# Altium schematic sheet sEMG_PowerManagment.SchDoc
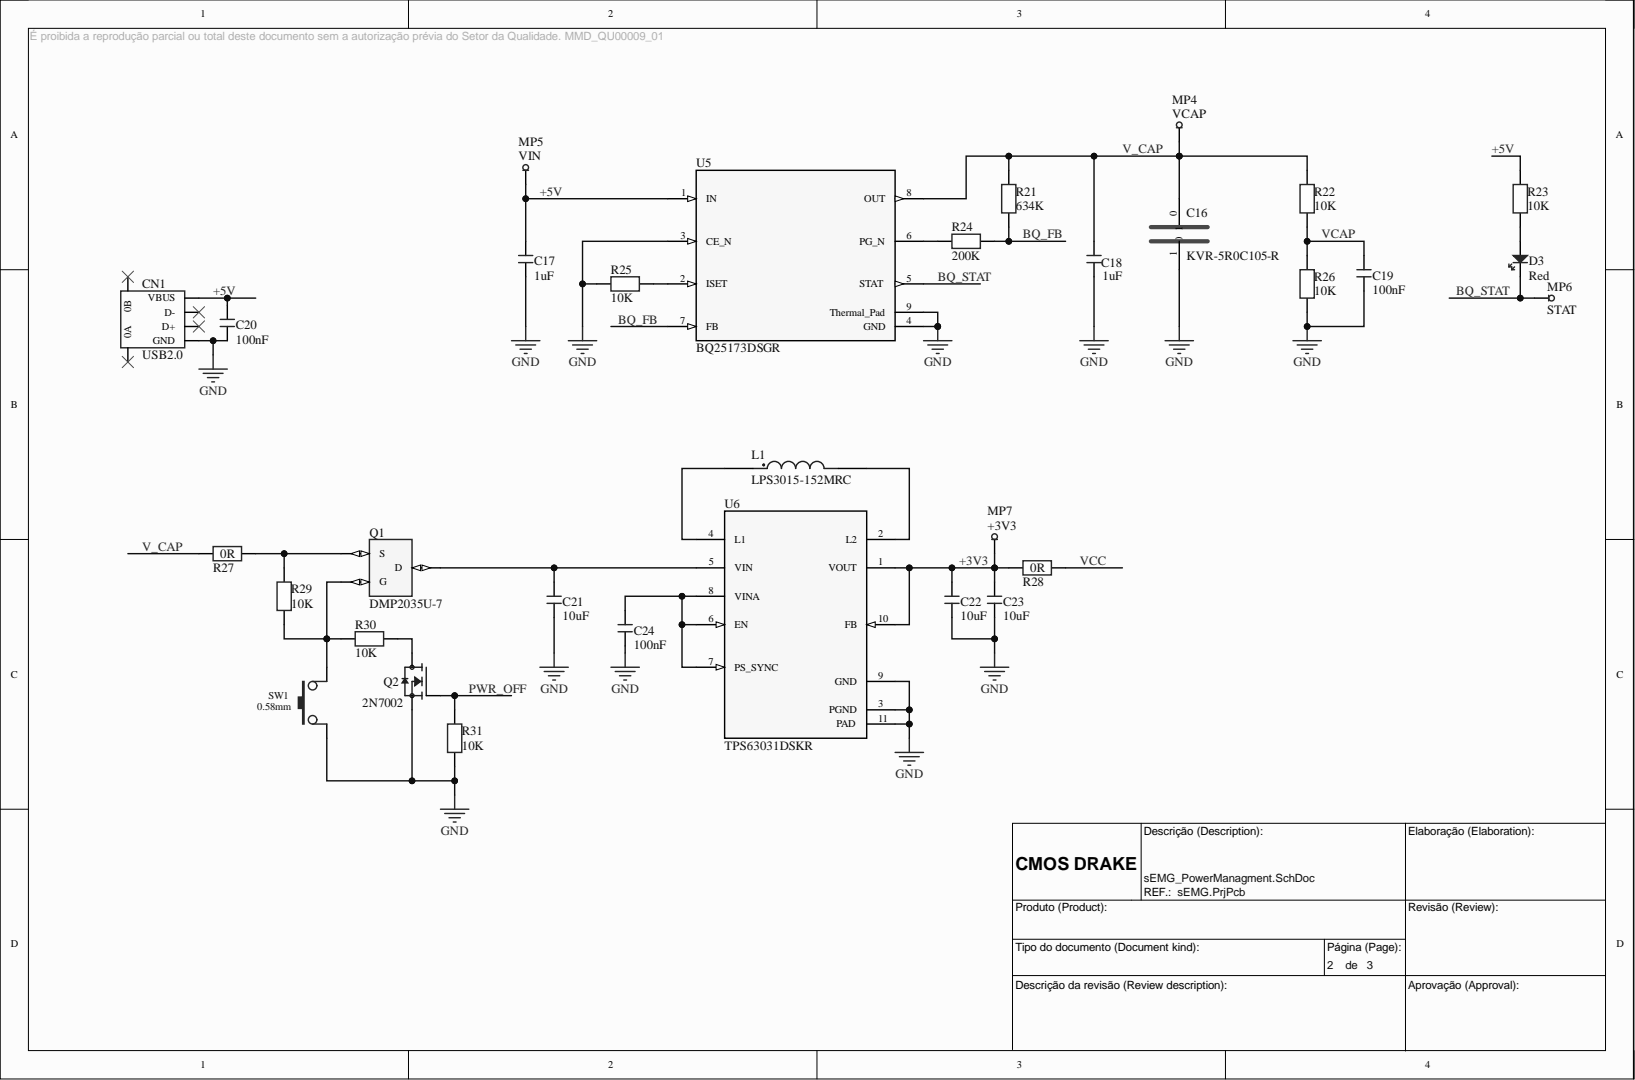
[diagram p0: sEMG_PowerManagment.SchDoc]

## Parts
C16  KVR-5R0C105-R
C17  1uF  [CAP0402[1005]MD]
C18  1uF  [CAP0402[1005]MD]
C19  100nF  [CAP0603[1608]MD-BO]
C20  100nF  [CAP0603[1608]MD-BO]
C21  10uF  [CAP0603[1608]MD]
C22  10uF  [CAP0603[1608]MD]
C23  10uF  [CAP0603[1608]MD]
C24  100nF  [CAP0603[1608]MD-BO]
CN1  USB2.0  [USB_TIPO B]
D3  Red  [LEDP0603RED]
L1  LPS3015-152MRC  [IND_LPS3015-152MRC]
MP4  VCAP  [MP1.02MM-RED]
MP5  VIN  [MP1.02MM-RED]
MP6  STAT  [MP1.02MM-RED]
MP7  +3V3  [MP1.02MM-RED]
Q1  DMP2035U-7  [SOT23]
Q2  2N7002  [TRANS_2N7002]
R21  634K  [RES0805[2012]MD]
R22  10K  [RES0805[2012]MD]
R23  10K  [RES0805[2012]MD]
R24  200K  [RES0805[2012]MD]
R25  10K  [RES0805[2012]MD]
R26  10K  [RES0805[2012]MD]
R27  0R  [RES0603[1608]MD-BO]
R28  0R  [RES0603[1608]MD-BO]
R29  10K  [RES0805[2012]MD]
R30  10K  [RES0805[2012]MD]
R31  10K  [RES0805[2012]MD]
SW1  0.58mm  [Tactile switch 6x6x7mm]
U5  BQ25173DSGR  [DSG0008A-IPC_A]
U6  TPS63031DSKR  [DSK0010A]

## Nets
GND: C16.1 C17.2 C18.2 C19.2 C20.2 C21.2 C22.2 C23.2 C24.2 CN1.4(GND) Q2.2 R25.2 R26.1 R31.2 SW1.1 U5.3(CE_N) U5.4(GND) U5.9(Thermal_Pad) U6.3(PGND) U6.9(GND) U6.11(PAD)
+3V3: C22.1 C23.1 MP7.1 R28.1 U6.1(VOUT) U6.10(FB)
+5V: C17.1 C20.1 CN1.1(VBUS) MP5.1 R23.2 U5.1(IN)
BQ_FB: R21.2 R24.2 U5.7(FB)
BQ_STAT: D3.1 MP6.1 U5.5(STAT)
PWR_OFF: Q2.1 R31.1
V_CAP: C16.0 C18.1 MP4.1 R21.1 R22.2 R27.1 U5.8(OUT)
VCAP: C19.1 R22.1 R26.2
VCC: R28.2
NetC21_1: C21.1 Q1.3 U6.5(VIN)
NetC24_1: C24.1 U6.6(EN) U6.7(PS_SYNC) U6.8(VINA)
NetD3_2: D3.2 R23.1
NetL1_1: L1.1 U6.4(L1)
NetL1_2: L1.2 U6.2(L2)
NetQ1_1: Q1.1 R29.2 R30.1 SW1.2
NetQ1_2: Q1.2 R27.2 R29.1
NetQ2_3: Q2.3 R30.2
NetR24_1: R24.1 U5.6(PG_N)
NetR25_1: R25.1 U5.2(ISET)

Not connected: CN1 0A 0B 2(D-) 3(D+)

## Library data of the parts
C16 = KVR-5R0C105-R: KVR-5R0C105-R 1F 5V  SUPERCAP; Component Kind: Standard; Library Name: KVR-5R0C105-R.SchLib
C17 C18 = SMD 0402 1uF 16V 10% X5R: CERAMIC SMD 0402 1uF 16V 10% X5R; Manufacturer 1: TDK; Part Number 1: C1005X5R1C105K; Component Kind: Standard; Library Name: Capacitors.SCHLIB; Manufacturer 2: Taiyo Yuden; Manufacturer 3: AVX; Part Number 2: EMK105BJ105KV-F; Part Number 3: 0402YD105KAT2A
C19 C20 C24 = SMD 0603 100nF 50V 5% X7R: CERAMIC SMD 0603 100nF 50V 5% X7R; Manufacturer 1: Samsung Electro-Mechanics America, Inc.; Part Number 1: CL10B104JB8NNNC; Component Kind: Standard; Library Name: Capacitors.SCHLIB; Manufacturer 2: Yageo; Manufacturer 3: AVX Corporation; Manufacturer 4: Murata Electronics North America; Manufacturer 5: KEMET; Part Number 2: CC0603JRX7R9BB104; Part Number 3: 06035C104JAT2A; Part Number 4: GRM188R71H104JA93D; Part Number 5: C0603C104J5RACTU
C21-C23 = SMD 0603 10uF 6.3V 10% X5R: CERAMIC SMD 0603 10uF 6.3V 10% X5R; Manufacturer 1: TDK Corporation; Part Number 1: C1608X5R0J106K; Component Kind: Standard; Library Name: Capacitors.SCHLIB
CN1 = USB2.0 B-SH-90: USB2.0 B SHIELDED; Component Kind: Standard; Library Name: Connectors.SCHLIB
D3 = LED VERMELHO SMD 0603 CLR: LED RED CLEAR 0603 SMD; Manufacturer 1: Kingbright Corp; Part Number 1: APT1608EC; Component Kind: Standard; Library Name: Diodes.SchLib
L1 = LPS3015-152MRC: Power inductor, shielded, 20% tol, SMT, RoHS, lead-free, halogen-free; MF: Coilcraft; Check_prices: https://www.snapeda.com/parts/LPS3015-152MRC/Coilcraft/view-part/?ref=eda; Component Kind: Standard; Library Name: LPS3015-152MRC.IntLib; MP: LPS3015-152MRC; Package: None; SnapEDA_Link: https://www.snapeda.com/parts/LPS3015-152MRC/Coilcraft/view-part/?ref=snap
MP4-MP7 = MP SIGNAL 1.02MM RED: MEASURE POINT PC MINI RED; Manufacturer 1: Keystone Electronics; Part Number 1: 5000; Component Kind: Standard; Library Name: Miscellaneous.SCHLIB
Q1 = DMP2035U-7: MOSFET P-Channel 20V 3.6A SOT23 | Diodes Inc DMP2035U-7; MF: Diodes Inc.; Check_prices: https://www.snapeda.com/parts/DMP2035U-7/Diodes+Inc./view-part/?ref=eda; Component Kind: Standard; Library Name: DMP2035U-7.IntLib; MP: DMP2035U-7; Package: SOT-23 Diodes Inc.; SnapEDA_Link: https://www.snapeda.com/parts/DMP2035U-7/Diodes+Inc./view-part/?ref=snap
Q2 = 2N7002: MOSFET, SOT-23, N, 60V, 0.28A, 5â„|, 150Â°C; MF: Diotec Semiconductor; Check_prices: https://www.snapeda.com/parts/2N7002/Diotec/view-part/?ref=eda; Component Kind: Standard; Library Name: 2N7002.IntLib; MP: 2N7002; Package: SOT-23 Diotec; SnapEDA_Link: https://www.snapeda.com/parts/2N7002/Diotec/view-part/?ref=snap
R21 = SMD 0805 10K 5% 0.125W: RESISTOR SMD 0805 634K 1% 0.25W; Manufacturer 1: Yageo; Part Number 1: RC0805JR-0710KL; Component Kind: Standard; Library Name: Resistors.SCHLIB; Manufacturer 2: Samsung Electro-Mechanics America, Inc; Manufacturer 3: Stackpole Electronics Inc; Manufacturer 4: Rohm Semiconductor; Part Number 2: RC2012J103CS; Part Number 3: RMCF0805JT10K0; Part Number 4: MCR10ERTJ103
R22 R23 R25 R26 R29-R31 = SMD 0805 10K 5% 0.125W: RESISTOR SMD 0805 10K 1% 0.25W; Manufacturer 1: Yageo; Part Number 1: RC0805JR-0710KL; Component Kind: Standard; Library Name: Resistors.SCHLIB; Manufacturer 2: Samsung Electro-Mechanics America, Inc; Manufacturer 3: Stackpole Electronics Inc; Manufacturer 4: Rohm Semiconductor; Part Number 2: RC2012J103CS; Part Number 3: RMCF0805JT10K0; Part Number 4: MCR10ERTJ103
R24 = SMD 0805 10K 5% 0.125W: RESISTOR SMD 0805 200K 1% 0.25W; Manufacturer 1: Yageo; Part Number 1: RC0805JR-0710KL; Component Kind: Standard; Library Name: Resistors.SCHLIB; Manufacturer 2: Samsung Electro-Mechanics America, Inc; Manufacturer 3: Stackpole Electronics Inc; Manufacturer 4: Rohm Semiconductor; Part Number 2: RC2012J103CS; Part Number 3: RMCF0805JT10K0; Part Number 4: MCR10ERTJ103
R27 R28 = SMD 0603 0R 1A 0.1W: RESISTOR SMD 0603 0R 0.1W; Manufacturer 1: Vishay; Part Number 1: RC0603JR-070RL; Component Kind: Standard; Library Name: Resistors.SCHLIB; Manufacturer 2: Stackpole Electronics Inc.; Manufacturer 3: Bourns Inc.; Manufacturer 4: TE Connectivity Passive Product; Part Number 2: RMCF0603ZT0R00; Part Number 3: CR0603-J/-000ELF; Part Number 4: CRG0603ZR
SW1 = TAC SWITCH 1P SPST-NO 0.05A 12V 0.58mm SMD: Manufacturer 1: E-Switch; Part Number 1: TL3780AF330QG; Component Kind: Standard; Description: TAC SWITCH 1P SPST-NO 0.05A 12V 0.58mm SMD; Library Name: Switches.SchLib; Manufacturer 2: Panasonic Electronic Components; Part Number 2: EVP-AWCD2A
U5 = BQ25173DSGR: 800-mA linear charger for 1-cell to 4-cell supercapacitor 8-WSON -40 to 125; Manufacturer_Name: Texas Instruments; Component Kind: Standard; Library Name: BQ25173DSGR.SchLib; Manufacturer_Part_Number: BQ25173DSGR; RefDes: RefDes; TYPE: TYPE; Copyright: Copyright (C) 2026 Ultra Librarian. All rights reserved.
U6 = TPS63031DSKR: High Efficient Single Inductor Buck-Boost Converter with 1-A Switches 10-SON -40 to 125; Alternate_PartNumber: TPS63031DSKT; Manufacturer: Texas Instruments; CompType: IC; Component Kind: Standard; Library Name: TPS63031DSKR.SchLib; Manufacturer_Name: Texas Instruments; Manufacturer_Part_Number: TPS63031DSKR; MountType: SMT; PackageReference: DSK0010A; PartNumber: TPS63031DSKR; RefDes: RefDes; RoHS: Y; TYPE: TYPE; Copyright: Copyright (C) 2026 Ultra Librarian. All rights reserved.
